# Altium schematic sheet System.SchDoc("Luchador System")
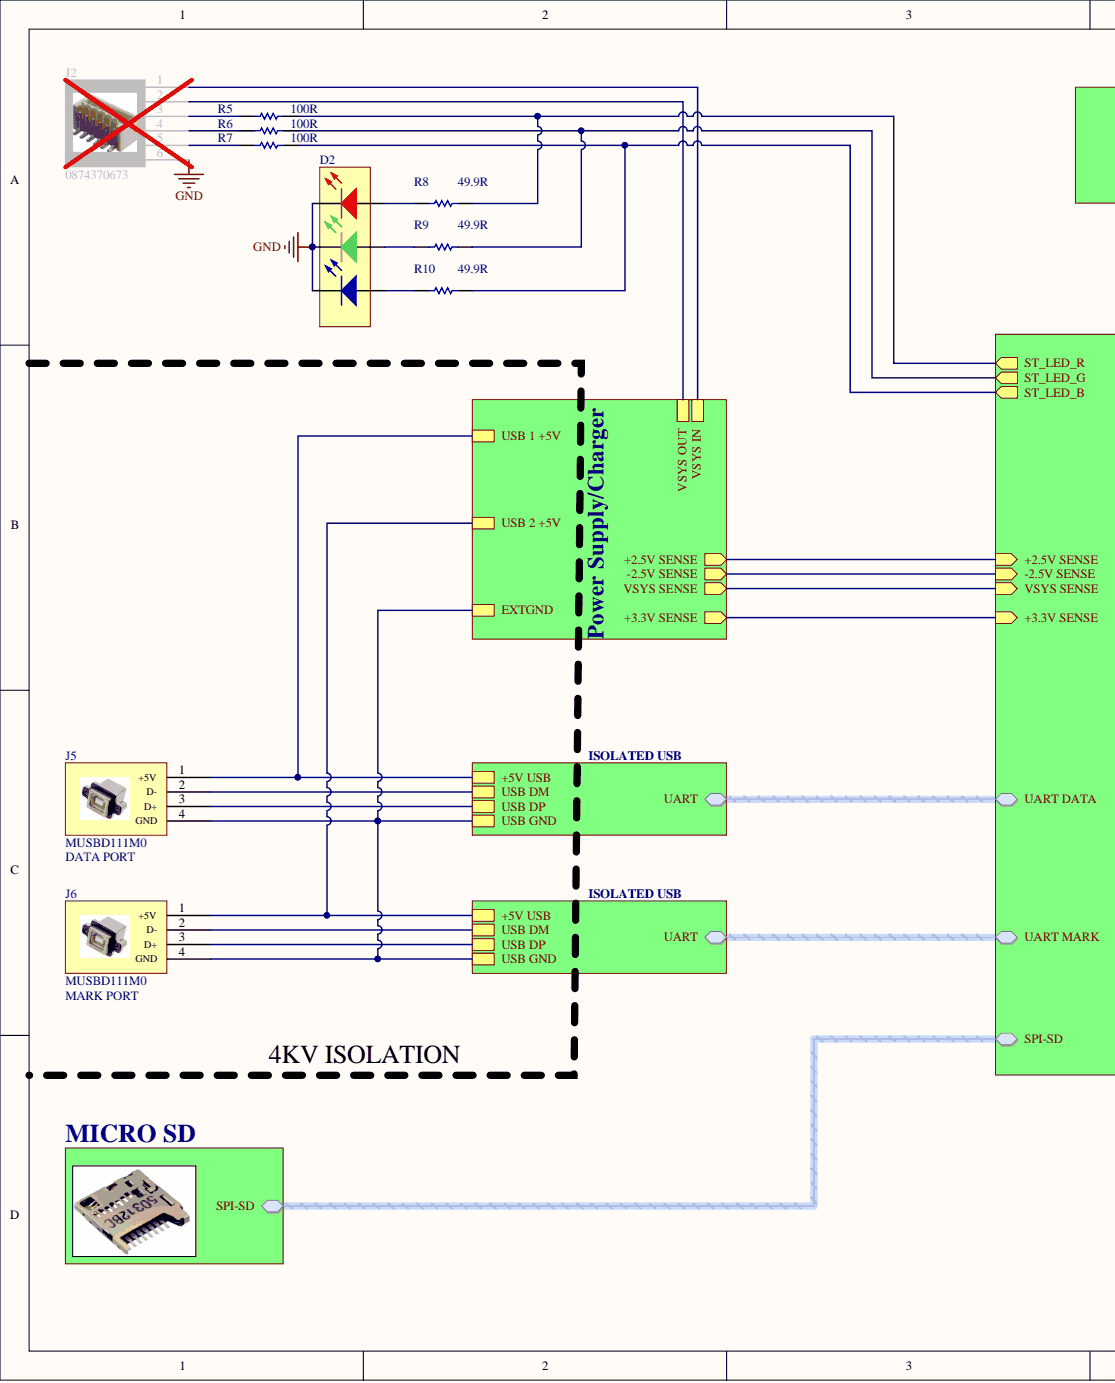
[diagram p0: System.SchDoc, left]
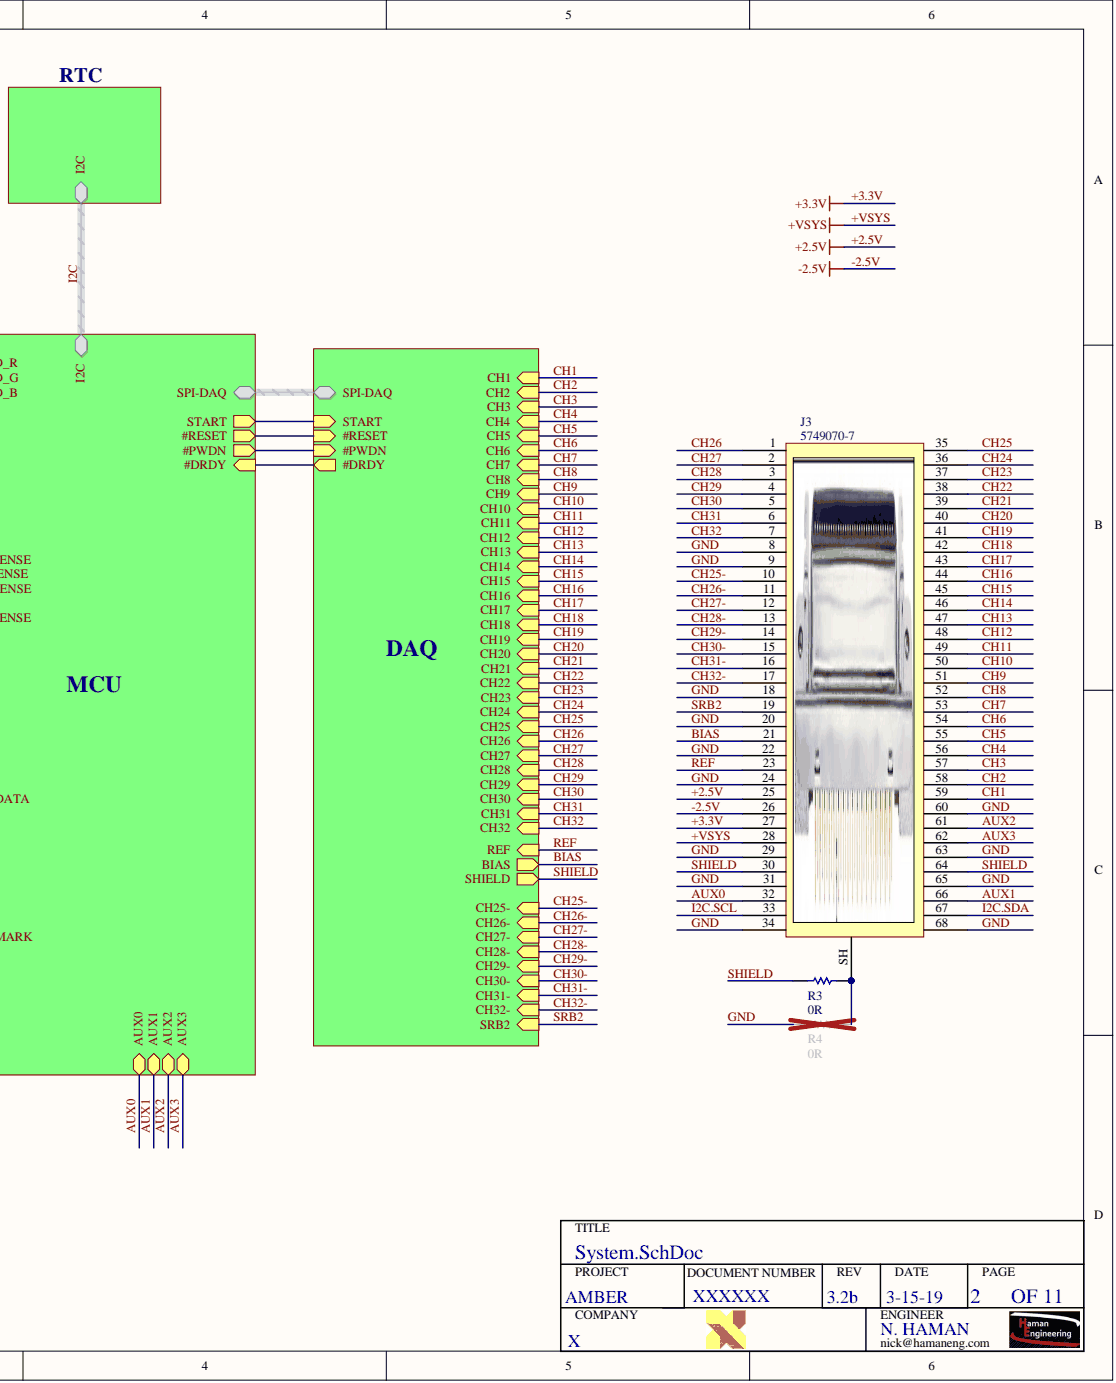
[diagram p1: System.SchDoc, right]

## Parts
D2  Component_2  [ASMB-TTB0-0A3A2]  (value not drawn)
J2  POWER SWITCH  [0874370673]  (value not drawn)
J3  10268-6212PC  [5749070-7]  (value not drawn)
J5  DATA PORT  [MUSBD111M0]
J6  MARK PORT  [MUSBD111M0]
R3  Resistance (Ohms): 0R  [0402R]  (hidden comment: Res 0805)
R4  Resistance (Ohms): 0R  [0402R]  (hidden comment: Res 0805)
R5  Resistance: 100R  [0402R]  (hidden comment: Res 0805)
R6  Resistance: 100R  [0402R]  (hidden comment: Res 0805)
R7  Resistance: 100R  [0402R]  (hidden comment: Res 0805)
R8  Resistance (Ohms): 49.9R  [0402R]  (hidden comment: Res 0805)
R9  Resistance (Ohms): 49.9R  [0402R]  (hidden comment: Res 0805)
R10  Resistance (Ohms): 49.9R  [0402R]  (hidden comment: Res 0805)

## Nets
+2.5V: J3.25
+3.3V: J3.27
+VSYS: J3.28
-2.5V: J3.26
AUX0: J3.32
AUX1: J3.66
AUX2: J3.61
AUX3: J3.62
BIAS: J3.21
CH1: J3.59
CH2: J3.58
CH3: J3.57
CH4: J3.56
CH5: J3.55
CH6: J3.54
CH7: J3.53
CH8: J3.52
CH9: J3.51
CH10: J3.50
CH11: J3.49
CH12: J3.48
CH13: J3.47
CH14: J3.46
CH15: J3.45
CH16: J3.44
CH17: J3.43
CH18: J3.42
CH19: J3.41
CH20: J3.40
CH21: J3.39
CH22: J3.38
CH23: J3.37
CH24: J3.36
CH25: J3.35
CH25-: J3.10
CH26: J3.1
CH26-: J3.11
CH27: J3.2
CH27-: J3.12
CH28: J3.3
CH28-: J3.13
CH29: J3.4
CH29-: J3.14
CH30: J3.5
CH30-: J3.15
CH31: J3.6
CH31-: J3.16
CH32: J3.7
CH32-: J3.17
GND: D2.1 D2.2 D2.3 J2.6 J3.8 J3.9 J3.18 J3.20 J3.22 J3.24 J3.29 J3.31 J3.34 J3.60 J3.63 J3.65 J3.68 R4.1
I2C.SCL: J3.33
I2C.SDA: J3.67
REF: J3.23
SHIELD: J3.30 J3.64 R3.1
SRB2: J3.19
NetD2_4: D2.4 R8.1
NetD2_5: D2.5 R9.1
NetD2_6: D2.6 R10.1
NetJ2_1: J2.1
NetJ2_2: J2.2
NetJ2_3: J2.3 R5.1
NetJ2_4: J2.4 R6.1
NetJ2_5: J2.5 R7.1
NetJ3_SH: J3.SH R3.2 R4.2
NetJ5_1: J5.1(+5V)
NetJ5_2: J5.2(D-)
NetJ5_3: J5.3(D+)
NetJ5_4: J5.4(GND) J6.4(GND)
NetJ6_1: J6.1(+5V)
NetJ6_2: J6.2(D-)
NetJ6_3: J6.3(D+)
NetR5_2: R5.2 R8.2
NetR6_2: R6.2 R9.2
NetR7_2: R7.2 R10.2
#DRDY:
#PWDN:
#RESET:
+2.5V SENSE:
+3.3V SENSE:
-2.5V SENSE:
SPI-DAQ.#CS0:
SPI-DAQ.#CS1:
SPI-DAQ.#CS2:
SPI-DAQ.#CS3:
SPI-DAQ.CLK:
SPI-DAQ.MISO:
SPI-DAQ.MOSI:
SPI-SD.#CS:
SPI-SD.CLK:
SPI-SD.MISO:
SPI-SD.MOSI:
START:
UART.MCU RX:
UART.MCU TX:
VSYS SENSE:

## Library data of the parts
D2 = Component_2: Manufacturer: Broadcom Avago; Wavelength - Peak: 635nm Red, 521nm Green, 464nm Blue; Wavelength - Dominant: 621nm Red, 530nm Green, 470nm Blue; Voltage - Forward (Vf) (Typ): 2.1V Red, 3.1V Green, 3.1V Blue; Viewing Angle: 115°; Millicandela Rating: 790mcd Red, 2400mcd Green, 500mcd Blue; Lens Transparency: Diffused; Lens Style/Size: Round with Flat Top; Description1: BROADCOM LIMITED   ASMB-TTB0-0A3A2   LED, RGB, Red, Green, Blue, R 790mcd, G 2.4cd, B 500mcd, 115 , R 2.1V, G 3.1V, B 3.1V; Current - Test: 20mA Red, 20mA Green, 20mA Blue; Color: Red, Green, Blue (RGB); Supplier Part Number 1: 516-3281-1-ND; Supplier Device Package: 6-PLCC; Supplier 1: Digi-Key; Stock 1: 165716; Size / Dimension: 3.50mm L x 3.50mm W; Series: -; Pricing 1: 1=1.57, 10=1.272, 25=0.9788, 100=0.8809, 250=0.783, 500=0.6656, 1000=0.5481 (USD); Packaging: Tape & Reel (TR); Package / Case: 6-SMD, J-Lead; Mounting Type: Surface Mount; Manufacturer Part Number: ASMB-TTB0-0A3A2; Lens Color: -; Height (Max): 2.80mm; Features: -; Family: LED Indication - Discrete; Component Kind: Standard; Category: Optoelectronics; Library Name: LUCHADOR SCHLIB.SchLib
J2 = 0874370673: Manufacturer: Molex; Pitch: 1.5 mm; Number of Contacts: 6; Gender: HDR; Description1: Conn Shrouded Header HDR 6 POS 1.5mm Solder ST SMD Embossed T/R; Type: Shrouded Header; Termination Method: Solder; Supplier Part Number 1: WM7141CT-ND; Supplier 1: Digi-Key; Stock 1: 9106; Pricing 1: 1=1.29, 10=1.131, 100=0.976 (USD); Number of Rows: 1; Manufacturer Part Number: 0874370673; Contact Plating: Tin Over Nickel; Component Kind: Standard; Category: Connector Headers and PCB Receptacles; Library Name: LUCHADOR SCHLIB.SchLib
J3 = 10268-6212PC: Manufacturer: AMP - TE CONNECTIVITY; Supplier Part Number 1: A31822-ND; Supplier 1: Digi-Key; Stock 1: 836; Pricing 1: 1=12.95, 16=11.7763, 32=11.4816, 56=10.5984, 104=10.0096, 256=9.1264, 504=8.5376, 1000=7.831 (USD); Manufacturer Part Number: 5749070-7; Component Kind: Standard; Library Name: LUCHADOR SCHLIB.SchLib
J5 J6 = MUSBD111M0: Manufacturer: Amphenol Commercial Products; Specifications: USB 2.0; Shielding: Shielded; Number of Contacts: 4; Mating Cycles: 1500; Material Flammability Rating: UL94 V-0; Ingress Protection: IP67 - Dust Tight, Waterproof; Gender: Receptacle; Description1: CONN RECPT RUGGED USB B IP67; Current Rating: 1.5A; Connector Type: USB - B; Voltage - Rated: -; Termination: Solder; Supplier Part Number 1: MUSBD111M0-ND; Supplier 1: Digi-Key; Stock 1: 4176; Shell/Shielding Material: Copper Alloy; RoHS: RoHS Compliant; Pricing 1: 1=9.81, 10=9.385, 25=8.532, 50=8.1054, 100=7.8921, 250=7.03892, 500=6.6123, 1000=6.162 (USD); Part Status: Active; Packaging: Bulk; Operating Temperature: -40°C ~ 105°C; Mounting Type: Panel Mount, Through Hole, Right Angle; Mounting Feature: Flange, Horizontal; Manufacturer Part Number: MUSBD111M0; Library Name: PI2PCUBS SCHLIB.SchLib; Insulation Color: White; Features: Board Guide; Contact Finish: Gold; ComponentLink1URL: https://www.amphenolcanada.com/ProductSearch/drawings/AC/MUSBD111XX.pdf; ComponentLink1Description: https://www.amphenolcanada.com/ProductSearch/drawings/AC/MUSBD111XX.pdf; Component Kind: Standard; Category: Connectors, Interconnects
R3 R4 = Res 0805: Resistor; Manufacturer Part Number: ERJ-2GE0R00X; Manufacturer: Panasonic; Tolerance: Jumper; Power (Watts): 0.1W, 1/10W; Other Names: P0.0JDKR; Online Catalog: ERJ Series; EDA / CAD Models: Download from Accelerated Designs; Description1: Res Thick Film 0402 0 Ohm Molded SMD Automotive T/R; Temperature Coefficient: -; Supplier Part Number 1: P0.0JCT-ND; Supplier Device Package: 0402; Supplier 1: Digi-Key; Stock 1: 31263960; Standard Package: 1; Size / Dimension: 0.039" L x 0.020" W (1.00mm x 0.50mm); Series: ERJ; Pricing 1: 1=0.1, 10=0.037, 25=0.0268, 50=0.0204, 100=0.015, 250=0.0114, 500=0.0091, 1000=0.0067, 5000=0.0048 (USD); Packaging: Digi-Reel®; Package / Case: 0402 (1005 Metric); Operating Temperature: -55°C ~ 155°C; Number of Terminations: 2; Height: 0.016" (0.40mm); Features: Automotive AEC-Q200; Family: Chip Resistor - Surface Mount; Composition: Thick Film; ComponentLink10URL: https://datasheet.ciiva.com/16778/70260739-16778335.pdf; ComponentLink10Description: https://datasheet.ciiva.com/16778/70260739-16778335.pdf; ComponentLink9URL: https://datasheet.ciiva.com/46389/1678796-46389518.pdf; ComponentLink9Description: https://datasheet.ciiva.com/46389/1678796-46389518.pdf; ComponentLink8URL: https://datasheet.ciiva.com/28695/1678796-28695758.pdf; ComponentLink8Description: https://datasheet.ciiva.com/28695/1678796-28695758.pdf; ComponentLink7URL: https://datasheet.ciiva.com/30097/4aoa0000ce1-30097116.pdf; ComponentLink7Description: https://datasheet.ciiva.com/30097/4aoa0000ce1-30097116.pdf; ComponentLink6URL: https://datasheet.ciiva.com/5959/1678796-5959692.pdf; ComponentLink6Description: https://datasheet.ciiva.com/5959/1678796-5959692.pdf; ComponentLink5URL: https://datasheet.ciiva.com/43460/1678796-43460347.pdf; ComponentLink5Description: https://datasheet.ciiva.com/43460/1678796-43460347.pdf; ComponentLink4URL: https://datasheet.ciiva.com/17215/aoa0000ce1-17215386.pdf; ComponentLink4Description: https://datasheet.ciiva.com/17215/aoa0000ce1-17215386.pdf; ComponentLink3URL: https://datasheet.ciiva.com/11821/0900766b80f806dd-11821176.pdf; ComponentLink3Description: https://datasheet.ciiva.com/11821/0900766b80f806dd-11821176.pdf; ComponentLink2URL: https://datasheet.ciiva.com/16783/70260739-16783734.pdf; ComponentLink2Description: https://datasheet.ciiva.com/16783/70260739-16783734.pdf; ComponentLink1URL: https://datasheet.ciiva.com/48531/aoa0000ce1-48531077.pdf; ComponentLink1Description: https://datasheet.ciiva.com/48531/aoa0000ce1-48531077.pdf; Component Kind: Standard; Category: Resistors; Library Name: RAPTOR MCB SCHLIB.SchLib
R5-R7 = Res 0805: Resistor; Manufacturer Part Number: ERJ-2RKF1000X; Manufacturer: Panasonic; Tolerance (%): ±1 %; Temp Coefficient: ±100 ppm/°C; Rated Power: 0.1 W; Description1: Res Thick Film 0402 100 Ohm 1% 0.1W(1/10W) ±100ppm/C Molded SMD Automotive T/R; Supplier Part Number 1: P100LCT-ND; Supplier 1: Digi-Key; Stock 1: 14075966; Size Code: 0402; Pricing 1: 1=0.1, 10=0.056, 100=0.0227, 1000=0.0102, 2500=0.0089, 5000=0.0073 (USD); ComponentLink13URL: https://datasheet.ciiva.com/28514/1678782-28514175.pdf; ComponentLink13Description: https://datasheet.ciiva.com/28514/1678782-28514175.pdf; ComponentLink12URL: https://datasheet.ciiva.com/16522/aoa0000ce2-16522621.pdf; ComponentLink12Description: https://datasheet.ciiva.com/16522/aoa0000ce2-16522621.pdf; ComponentLink11URL: https://datasheet.ciiva.com/7830/426256-7830973.pdf; ComponentLink11Description: https://datasheet.ciiva.com/7830/426256-7830973.pdf; ComponentLink10URL: https://datasheet.ciiva.com/17207/aoa0000ce2-17207980.pdf; ComponentLink10Description: https://datasheet.ciiva.com/17207/aoa0000ce2-17207980.pdf; ComponentLink9URL: https://datasheet.ciiva.com/48531/aoa0000ce2-48531067.pdf; ComponentLink9Description: https://datasheet.ciiva.com/48531/aoa0000ce2-48531067.pdf; ComponentLink8URL: https://datasheet.ciiva.com/16776/70260748-16776427.pdf; ComponentLink8Description: https://datasheet.ciiva.com/16776/70260748-16776427.pdf; ComponentLink7URL: https://datasheet.ciiva.com/9186/aoa0000ce2-9186683.pdf; ComponentLink7Description: https://datasheet.ciiva.com/9186/aoa0000ce2-9186683.pdf; ComponentLink6URL: https://datasheet.ciiva.com/30260/aoa0000ce2-30260809.pdf; ComponentLink6Description: https://datasheet.ciiva.com/30260/aoa0000ce2-30260809.pdf; ComponentLink5URL: https://datasheet.ciiva.com/43467/1678782-43467223.pdf; ComponentLink5Description: https://datasheet.ciiva.com/43467/1678782-43467223.pdf; ComponentLink4URL: https://datasheet.ciiva.com/16199/aoa0000ce2-16199575.pdf; ComponentLink4Description: https://datasheet.ciiva.com/16199/aoa0000ce2-16199575.pdf; ComponentLink3URL: https://datasheet.ciiva.com/46372/1678782-46372741.pdf; ComponentLink3Description: https://datasheet.ciiva.com/46372/1678782-46372741.pdf; ComponentLink2URL: https://datasheet.ciiva.com/11821/0900766b80f80784-11821173.pdf; ComponentLink2Description: https://datasheet.ciiva.com/11821/0900766b80f80784-11821173.pdf; ComponentLink1URL: https://datasheet.ciiva.com/27056/2007311-27056035.pdf; ComponentLink1Description: https://datasheet.ciiva.com/27056/2007311-27056035.pdf; Component Kind: Standard; Library Name: RAPTOR MCB SCHLIB.SchLib
R8-R10 = Res 0805: Resistor; Manufacturer Part Number: RC0402FR-0749R9L; Manufacturer: Yageo; Tolerance: ±1%; Temperature Coefficient: ±100ppm/°C; Product Training Modules: Chip Resistor; Power (Watts): 0.063W, 1/16W; Other Names: 311-49.9LRCT; Online Catalog: RC0402 Series; Kits: PHL1-KIT-ND  - RESISTOR KIT 1-75 1/16W 850PCS; HTML Datasheet: RC Series, L Suffix Datasheet.html; EDA / CAD Models: Download from Accelerated Designs; Description1: RES SMD 49.9 OHM 1% 1/16W 0402; Catalog Drawings: Thick Film Resistor Top Thick Film Resistor Side; Supplier Part Number 1: 311-49.9LRCT-ND; Supplier Device Package: 0402; Supplier 1: Digi-Key; Stock 1: 22199663; Standard Package: 1; Size / Dimension: 0.039" L x 0.020" W (1.00mm x 0.50mm); Series: RC; Pricing 1: 1=0.1, 10=0.022, 100=0.009, 1000=0.004, 2500=0.0035, 5000=0.0029 (USD); Packaging: Cut Tape (CT); Package / Case: 0402 (1005 Metric); Operating Temperature: -55°C ~ 155°C; Number of Terminations: 2; Height: 0.016" (0.40mm); Features: Moisture Resistant; Family: Chip Resistor - Surface Mount; Composition: Thick Film; Component Kind: Standard; Category: Resistors; Library Name: RAPTOR MCB SCHLIB.SchLib
